# Altium schematic sheet AquaboxII_2.SchDoc
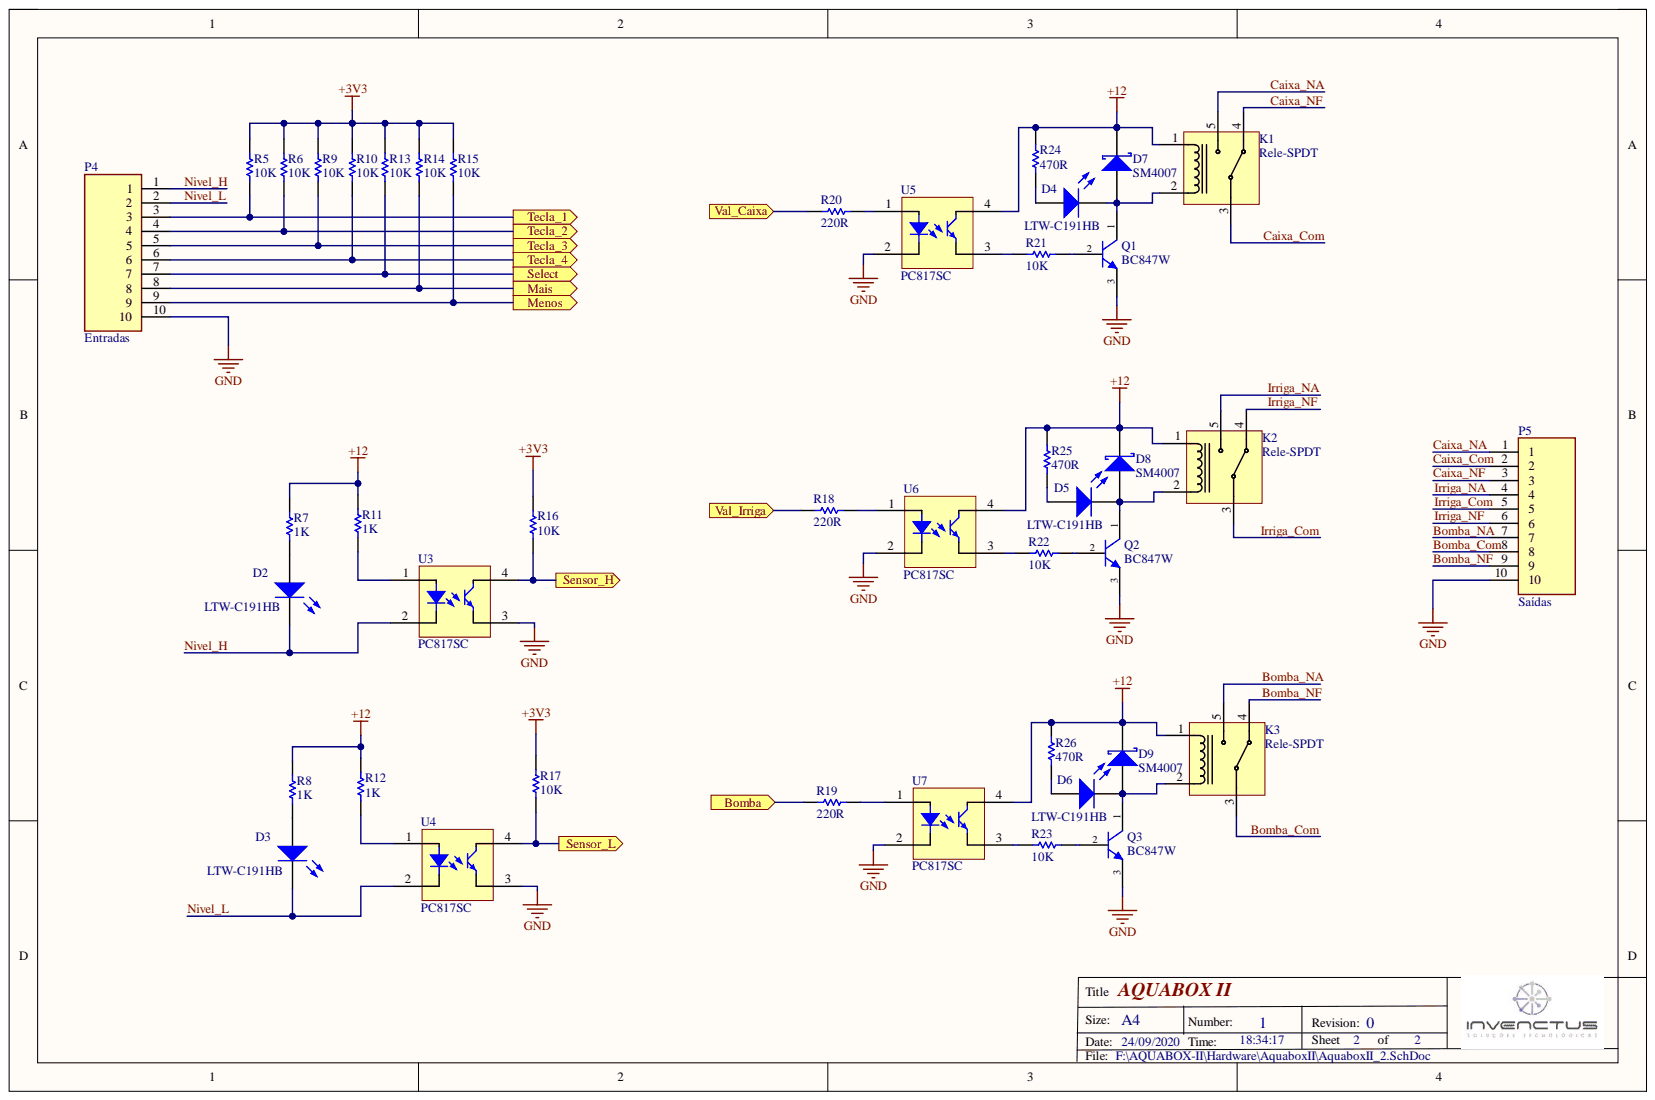
[diagram p0: AquaboxII_2.SchDoc]

## Parts
D2  LTW-C191HB  [LED-0603-R]
D3  LTW-C191HB  [LED-0603-R]
D4  LTW-C191HB  [LED-0603-R]
D5  LTW-C191HB  [LED-0603-R]
D6  LTW-C191HB  [LED-0603-R]
D7  SM4007  [DO214AC]
D8  SM4007  [DO214AC]
D9  SM4007  [DO214AC]
K1  Rele-SPDT  [RELE-SPDT]
K2  Rele-SPDT  [RELE-SPDT]
K3  Rele-SPDT  [RELE-SPDT]
P4  Entradas  [Block 10 Vias]
P5  Saídas  [Block 10 Vias]
Q1  BC847W  [SOT23]
Q2  BC847W  [SOT23]
Q3  BC847W  [SOT23]
R5  10K  [R2012[0805]]
R6  10K  [R2012[0805]]
R7  1K  [R2012[0805]]
R8  1K  [R2012[0805]]
R9  10K  [R2012[0805]]
R10  10K  [R2012[0805]]
R11  1K  [R2012[0805]]
R12  1K  [R2012[0805]]
R13  10K  [R2012[0805]]
R14  10K  [R2012[0805]]
R15  10K  [R2012[0805]]
R16  10K  [R2012[0805]]
R17  10K  [R2012[0805]]
R18  220R  [R2012[0805]]
R19  220R  [R2012[0805]]
R20  220R  [R2012[0805]]
R21  10K  [R2012[0805]]
R22  10K  [R2012[0805]]
R23  10K  [R2012[0805]]
R24  470R  [R2012[0805]]
R25  470R  [R2012[0805]]
R26  470R  [R2012[0805]]
U3  PC817SC  [LITEON LTV-817]
U4  PC817SC  [LITEON LTV-817]
U5  PC817SC  [LITEON LTV-817]
U6  PC817SC  [LITEON LTV-817]
U7  PC817SC  [LITEON LTV-817]

## Ports
Bomba, Mais, Menos, Select, Sensor_H, Sensor_L, Tecla_1, Tecla_2, Tecla_3, Tecla_4, Val_Caixa, Val_Irriga

## Nets
+3V3: R5.2 R6.2 R9.2 R10.2 R13.2 R14.2 R15.2 R16.2 R17.2
+12: D7.2 D8.2 D9.2 K1.1 K2.1 K3.1 R7.2 R8.2 R11.2 R12.2 R24.1 R25.1 R26.1 U5.4 U6.4 U7.4
GND: P4.10 P5.10 Q1.3 Q2.3 Q3.3 U3.3 U4.3 U5.2 U6.2 U7.2
Bomba: R19.2 ; port Bomba
Bomba_Com: K3.3 P5.8
Bomba_NA: K3.5 P5.7
Bomba_NF: K3.4 P5.9
Caixa_Com: K1.3 P5.2
Caixa_NA: K1.5 P5.1
Caixa_NF: K1.4 P5.3
Irriga_Com: K2.3 P5.5
Irriga_NA: K2.5 P5.4
Irriga_NF: K2.4 P5.6
Mais: P4.8 R14.1 ; port Mais
Menos: P4.9 R15.1 ; port Menos
Nivel_H: D2.2 P4.1 U3.2
Nivel_L: D3.2 P4.2 U4.2
Select: P4.7 R13.1 ; port Select
Sensor_H: R16.1 U3.4 ; port Sensor_H
Sensor_L: R17.1 U4.4 ; port Sensor_L
Tecla_1: P4.3 R5.1 ; port Tecla_1
Tecla_2: P4.4 R6.1 ; port Tecla_2
Tecla_3: P4.5 R9.1 ; port Tecla_3
Tecla_4: P4.6 R10.1 ; port Tecla_4
Val_Caixa: R20.2 ; port Val_Caixa
Val_Irriga: R18.2 ; port Val_Irriga
NetD2_1: D2.1 R7.1
NetD3_1: D3.1 R8.1
NetD4_1: D4.1 R24.2
NetD4_2: D4.2 D7.1 K1.2 Q1.1
NetD5_1: D5.1 R25.2
NetD5_2: D5.2 D8.1 K2.2 Q2.1
NetD6_1: D6.1 R26.2
NetD6_2: D6.2 D9.1 K3.2 Q3.1
NetQ1_2: Q1.2 R21.1
NetQ2_2: Q2.2 R22.1
NetQ3_2: Q3.2 R23.1
NetR11_1: R11.1 U3.1
NetR12_1: R12.1 U4.1
NetR18_1: R18.1 U6.1
NetR19_1: R19.1 U7.1
NetR20_1: R20.1 U5.1
NetR21_2: R21.2 U5.3
NetR22_2: R22.2 U6.3
NetR23_2: R23.2 U7.3

## Library data of the parts
D2-D6 = LED: Component Kind: Standard; Description: LED; Library Name: Basic Library.IntLib; Simulation: LED0
D7-D9 = D Schottky: Schottky Diode; Component Kind: Standard; Library Name: Diodos.IntLib; Signal Integrity: D Schottky; Simulation: SKYDIODE
P4 P5 = Block 10 Vias: Component Kind: Standard; Library Name: Conectores.IntLib
Q1-Q3 = NPN: NPN Bipolar Transistor; Component Kind: Standard; Library Name: Transistores.IntLib; Signal Integrity: NPN; Simulation: NPN
R5-R26 = R: Resistor; Value: 0; Component Kind: Standard; Signal Integrity: Res Semi; Simulation: RES; Library Name: Resistores.IntLib
U3-U7 = PC817SC: PhotoAcoplador SMD-4; Component Kind: Standard; Library Name: Optos.IntLib
K1-K3 = Rele-SPDT: Component Kind: Standard; Library Name: Components Library.IntLib
